# Altium schematic sheet lv_vbus.SchDoc(lv_vbus)
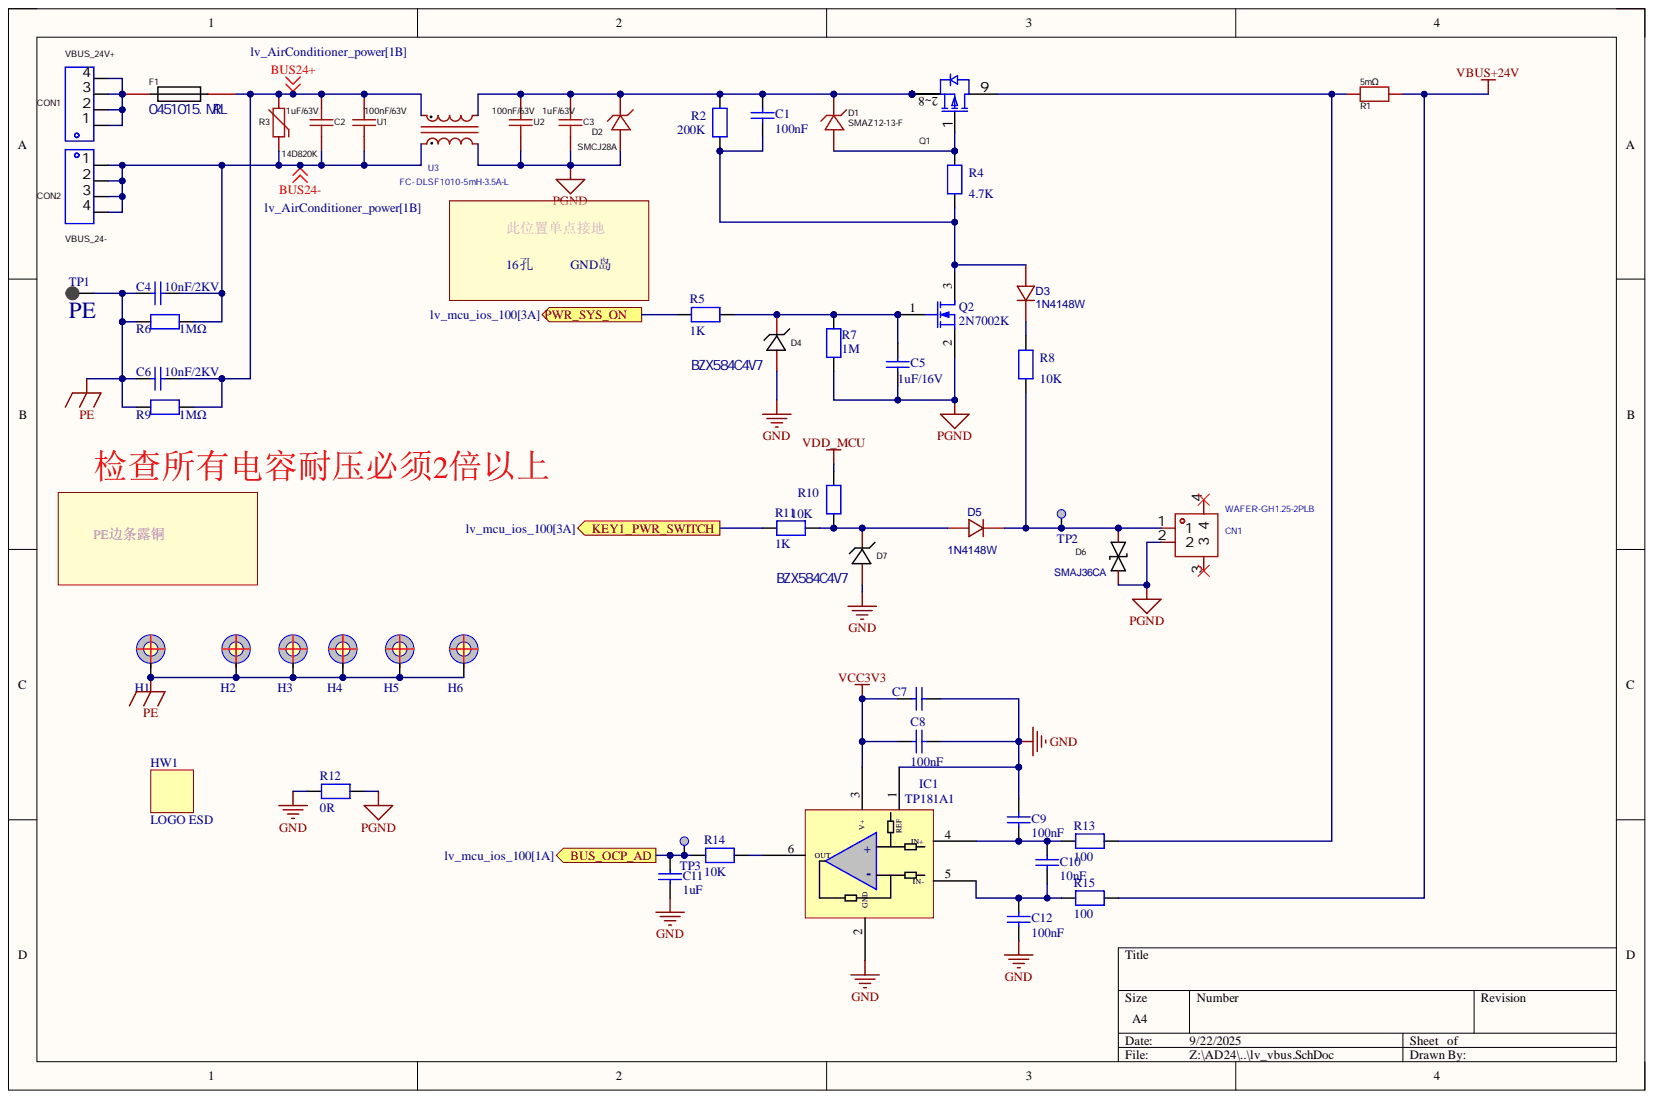
[diagram p0: lv_vbus.SchDoc]

## Parts
C1  100nF  [C0402]
C2  1uF/63V  [CAP-TH_L7.2-W5.0-P5.00-D1.0]
C3  1uF/63V  [CAP-TH_L7.2-W5.0-P5.00-D1.0]
C4  10nF/2KV  [c1812]
C5  1uF/16V  [C0603]
C6  10nF/2KV  [c1812]
C7  1uF/10V  [C0402]  (value not drawn)
C8  100nF  [C0402]
C9  100nF  [C0402]
C10  10nF  [C0402]
C11  1uF  [C0402]
C12  100nF  [C0402]
CN1  WAFER-GH1.25-2PLB  [CONN-TH_2P-P1.25_XUNPU_WAFER-GH1.25-2PLB]
CON1  VBUS_24V+  [CONN-TH_T44001]
CON2  VBUS_24-  [CONN-TH_T44001]
D1  SMAZ12-13-F  [SMA_L4.3-W2.6-LS5.2-RD]
D2  SMCJ28A  [SMC_L6.9-W5.9-LS7.9-RD]
D3  1N4148W  [SOD-123_L2.8-W1.8-LS3.7-RD]
D4  BZX584C4V7  [SOD-523F_L1.2-W0.8-LS1.7-RD]
D5  1N4148W  [SOD-123_L2.8-W1.8-LS3.7-RD]
D6  SMAJ36CA  [SMA_L4.4-W2.6-LS5.0-BI]
D7  BZX584C4V7  [SOD-523F_L1.2-W0.8-LS1.7-RD]
F1  0451015.MRL  [FUSE-SMD_L6.1-W2.6]
H1  POSITION HOLE C7D3R5  [PTH_350_700]  (value not drawn)  (no BOM)
H2  POSITION HOLE C7D3R5  [PTH_350_700]  (value not drawn)  (no BOM)
H3  POSITION HOLE C7D3R5  [PTH_350_700]  (value not drawn)  (no BOM)
H4  POSITION HOLE C7D3R5  [PTH_350_700]  (value not drawn)  (no BOM)
H5  POSITION HOLE C7D3R5  [PTH_350_700]  (value not drawn)  (no BOM)
H6  POSITION HOLE C7D3R5  [PTH_350_700]  (value not drawn)  (no BOM)
HW1  value LOGO ESD  [LOGO_MAIN_1]  (hidden comment: Logo_2)  (no BOM)
IC1  TP181A1  [SC-70-6_L2.2-W1.3-P0.65-LS2.1-BL]
Q1  SP60P03GHTO  [TOLL-8_L10.4-W9.9-P1.20-LS11.7-BL]  (value not drawn)
Q2  2N7002K  [SOT-23(SOT-23-3)]
R1  5mΩ  [RES-SMD_L7.1-W4.3_R2817]
R2  200K  [R0603]
R3  14D820K  [RES-TH_L16.5-W4.1-P7.50-D0.8-S4.10]
R4  4.7K  [0805]
R5  1K  [R0402]
R6  1MΩ  [1206]
R7  1M  [R0402]
R8  10K  [0805]
R9  1MΩ  [1206]
R10  10K  [R0402]
R11  1K  value 10K  [R0402]
R12  0R  [1206]
R13  100  [R0402]
R14  10K  [R0402]
R15  100  [R0402]
TP1  PE  [TP-1.5]
TP2  Test Point  [TP_SMD_1MM]  (value not drawn)  (no BOM)
TP3  Test Point  [TP_SMD_1MM]  (value not drawn)  (no BOM)
U1  100nF/63V  [CAP-TH_L7.2-W2.5-P5.00-D1.0]
U2  100nF/63V  [CAP-TH_L7.2-W2.5-P5.00-D1.0]
U3  FC- DLSF1010-5mH-3.5A-L  [XFMR-TH_FC-DLSF1010]

## Ports
BUS_OCP_AD, KEY1_PWR_SWITCH, PWR_SYS_ON

## Nets
BUS24+: C2.2 C6.2 F1.2 R3.2 R9.2 U1.2 U3.1
BUS24-: C2.1 C4.2 CON2.1 CON2.2 CON2.3 CON2.4 R3.1 R6.2 U1.1 U3.4
GND: C7.1 C8.2 C9.1 C11.2 C12.2 D4.2 D7.2 IC1.1(REF) IC1.2(GND) R12.1
PE: C4.1 C6.1 H1.1 H2.1 H3.1 H4.1 H5.1 H6.1 R6.1 R9.1 TP1.1
PGND: C3.1 C5.2 CN1.2 D2.2 D6.2 Q2.2 R7.1 R12.2 U2.1 U3.3
VBUS+24V: R1.2 R15.2
VCC3V3: C7.2 C8.1 IC1.3(V+)
VDD_MCU: R10.1
BUS_OCP_AD: C11.1 R14.2 TP3.1 ; port BUS_OCP_AD
KEY1_PWR_SWITCH: R11.1 ; port KEY1_PWR_SWITCH
PWR_SYS_ON: R5.1 ; port PWR_SYS_ON
NetC1_1: C1.1 D3.2 Q2.3 R2.2 R4.2
NetC1_2: C1.2 C3.2 D1.1 D2.1 Q1.2 Q1.3 Q1.4 Q1.5 Q1.6 Q1.7 Q1.8 R2.1 U2.2 U3.2
NetC5_1: C5.1 D4.1 Q2.1 R5.2 R7.2
NetC9_2: C9.2 C10.1 IC1.4(IN+) R13.1
NetC10_2: C10.2 C12.1 IC1.5(IN-) R15.1
NetCN1_1: CN1.1 D5.1 D6.1 R8.2 TP2.1
NetCON1_1: CON1.1 CON1.2 CON1.3 CON1.4 F1.1
NetD1_2: D1.2 Q1.1 R4.1
NetD3_1: D3.1 R8.1
NetD5_2: D5.2 D7.1 R10.2 R11.2
NetIC1_6: IC1.6(OUT) R14.1
NetQ1_9: Q1.9 R1.1 R13.2

Not connected: CN1 3 4

## Library data of the parts
C1 C8 C9 C12 = Capacitor: 50V, 10%, X7R; Internal_Part_Number: MCD-00003; Manufacturer: muRata; Manufacturer_Part_Number: GRM155R71H104KE14D; Component Kind: Standard; ComponentLink1Description: Datasheet; ComponentLink1URL: http://tunmcdsvn.tun.st.com/Component_Datasheet_Library/Trunk/Capacitors/Yageo_Capacitor_NP0_16V-to-50V; Library Name: HiPin_Library_AD.IntLib; Package: C0402; Value: 100nF
C2 C3 = MEB105J63V82CB0235: 精度±5%,额定电压63V,金属化聚酯; Manufacturer: KNSCHA(科尼盛); Component Kind: Standard; Library Name: HiPin_Library_AD.IntLib; Manufacturer_Part_Number: MEB105J63V82CB0235
C4 C6 = Capacitor_3: 2KV, X7R, 10%; Manufacturer: FH; Manufacturer_Part_Number: 1812B103K202NT; Component Kind: Standard; Library Name: HiPin_Library_AD.IntLib
C5 = MCD-00238: Manufacturer: YAGEO; Manufacturer_Part_Number: CC0603KRX5R7BB105; Database Table Name: MCD_PARTS$; Component Kind: Standard; ComponentLink1Description: Datasheet; ComponentLink1URL: http://tunmcdsvn.tun.st.com/Component_Datasheet_Library/Trunk/Capacitors/Yageo_Multilayer_capacitor_X5R_series_100pF_to_100uF; Library Name: MCD_PARTS_database.DbLib
C7 = Capacitor: 10V, X7R, ±10%; Manufacturer: muRata; Manufacturer_Part_Number: GRM155Z71A105KE01D; Component Kind: Standard; ComponentLink1Description: Datasheet; ComponentLink1URL: http://tunmcdsvn.tun.st.com/Component_Datasheet_Library/Trunk/Capacitors/Yageo_Capacitor_NP0_16V-to-50V; Library Name: HiPin_Library_AD.IntLib
C10 = Capacitor: 50V, 10%, X7R; Internal_Part_Number: MCD-00003; Manufacturer_Part_Number: GRM155R71H103KA88D; Component Kind: Standard; ComponentLink1Description: Datasheet; ComponentLink1URL: http://tunmcdsvn.tun.st.com/Component_Datasheet_Library/Trunk/Capacitors/Yageo_Capacitor_NP0_16V-to-50V; Library Name: HiPin_Library_AD.IntLib; Package: C0402; Value: 10nF
C11 = Capacitor: 10V, 10%, X7R; Internal_Part_Number: MCD-00003; Manufacturer_Part_Number: GRM155Z71A105KE01D; Component Kind: Standard; ComponentLink1Description: Datasheet; ComponentLink1URL: http://tunmcdsvn.tun.st.com/Component_Datasheet_Library/Trunk/Capacitors/Yageo_Capacitor_NP0_16V-to-50V; Library Name: HiPin_Library_AD.IntLib; Package: C0402; Value: 1uF
CN1 = WAFER-GH1.25-2PLB: 插座系列: GH,  立贴结构: 1x2P, 间距: 1.25mm; Manufacturer: XUNPU(讯普); Add into BOM: yes; Current Rating: 1A; Number of PINs Per Row: 2; Plastic Material: LCP; Supplementary Features: 辅助焊脚; Voltage Rating: 125V; X-Length of Bottom Edge on Board (Spacing Line): 5.75mm; Y-Width of Bottom Edge on Board: 4.95mm; Z-Height of the Board: 4.2mm; Color: 米色; Component Kind: Standard; Contact Material: 黄铜; Contact Plating: -; Convert to PCB: yes; Datasheet: https://atta.szlcsc.com/upload/public/pdf/source/20220527/44F824AAC8C2B617205E15955FF9C23D.pdf; Device: WAFER-GH1.25-2PLB; Flame Retardant Rating: -; JLCPCB Part Class: Extended Part; LCSC Part Name: 1x2P 间距:1.25mm 立贴 系列:GH; Library Name: HiPin_Library_AD.IntLib; Manufacturer Part: WAFER-GH1.25-2PLB; Mounting Type: 立贴; Number of Pins: 2P; Number of Rows: 1; Operating Temperature: -25℃~+85℃; PCB3D: CONN-TH_2P-P1.25_XUNPU_WAFER-GH1.25-2PLB; Pins Structure: 1x2P; Pitch: 1.25mm; Reference Series: GH; Row Spacing: -; Supplier: LCSC; Supplier Footprint: SMD,P=1.25mm; Supplier Part: C3029368; Symbol: WAFER-GH1.25-2PLB
CON1 CON2 = T44001: Manufacturer: XFCN(兴飞); Add into BOM: yes; Component Kind: Standard; Convert to PCB: yes; Datasheet: https://atta.szlcsc.com/upload/public/pdf/source/20200107/C481448_4214B4EB74A46B70BD82BA8A456D4EDD.pdf; Device: T44001; JLCPCB Part Class: Extended Part; LCSC Part Name: 四脚 M4 二件套; Library Name: HiPin_Library_AD.IntLib; Manufacturer Part: T44001; Supplier: LCSC; Supplier Footprint: 插件; Supplier Part: C481447; Symbol: T44001
D1 = SMAZ12-13-F: 稳压二极管; Manufacturer: DIODES(美台); Add into BOM: yes; Diode Configuration: 独立式; Power Dissipation: 1W; Reverse Leakage Current (Ir): 1uA@9.1V; Tolerance: ±5%; Zener Impedance (Zzt): 7Ω; Zener Voltage (Nom): 12V; Zener Voltage (Range): 11.4V~12.6V; Component Kind: Standard; Convert to PCB: yes; Datasheet: https://atta.szlcsc.com/upload/public/pdf/source/20191028/C442456_7FB4DBCAA017352A40A80784B71299E4.pdf; Device: SMAZ12-13-F; JLCPCB Part Class: Extended Part; LCSC Part Name: SMAZ12-13-F; Library Name: HiPin_Library_AD.IntLib; Manufacturer Part: SMAZ12-13-F; PCB3D: SMA_L4.3-W2.6-LS5.2-RD; Supplier: LCSC; Supplier Footprint: SMA(DO-214AC); Supplier Part: C110523; Symbol: SMAZ12-13-F
D2 = SMCJ28A_C693618: Manufacturer: FTR(乔光电子); Add into BOM: yes; Breakdown Voltage: 31.1V; Maximum Clamping Voltage: 45.4V; Peak Pulse Current (Ipp)@10/1000us: 33.1A; polarity: 单向; Reverse Stand-Off Voltage (Vrwm): 28V; Type: TVS; Component Kind: Standard; Convert to PCB: yes; Datasheet: https://atta.szlcsc.com/upload/public/pdf/source/20200702/C693547_038122F463C15F3D55BC5385490E6510.pdf; Device: SMCJ28A_C693618; JLCPCB Part Class: Extended Part; LCSC Part Name: SMCJ28A; Library Name: HiPin_Library_AD.IntLib; Manufacturer Part: SMCJ28A; Supplier: LCSC; Supplier Footprint: SMC(DO-214AB); Supplier Part: C693618; Symbol: SMCJ28A_C693618
D3 D5 = 1N4148W-C84367: BOM_Manufacturer: MDD; BOM_JLCPCB Part Class: Extended Part; BOM_Manufacturer Part: 1N4148W; BOM_Supplier: LCSC; BOM_Supplier Part: C84367; Component Kind: Standard; Library Name: HiPin_Library_AD.IntLib; spicePre: D; spiceSymbolName: 1N4148W-C84367
D4 D7 = LM5Z3V6T1G: 4.8~5.4V, 200mW; Power Dissipation: 500mW; Component Kind: Standard; Library Name: HiPin_Library_AD.IntLib; Manufacturer Part: BZX584C4V7; Supplier Footprint: SOD-123; Tolerance: -
  D7: PCB3D: SOD-123_L2.7-W1.6-H1.4-LS3.7
D6 = SMAJ6.8CA_C406209: 极性: 双向  反向截止电压(Vrwm): 36V  钳位电压: 58.1V  峰值脉冲电流(Ipp): 6.9A; Manufacturer: BORN(�����뵼��); Add into BOM: yes; Reverse Stand-Off Voltage (Vrwm): 6.8V; Type: TVS; Component Kind: Standard; Convert to PCB: yes; Datasheet: https://atta.szlcsc.com/upload/public/pdf/source/20190726/C406209_A574BC8626299E1E05AC1B67D2991239.pdf; JLCPCB Part Class: Extended Part; LCSC Part Name: ˫��TVS 6.8V��ֹ; Library Name: HiPin_Library_AD.IntLib; Manufacturer Part: SMAJ36CA; Supplier: LCSC; Supplier Footprint: SMA(DO-214AC); Supplier Part: C406209
F1 = 0451015.MRL: Manufacturer: Littelfuse(美国力特); Add into BOM: yes; Breaking Capacity: 50A; Current Rating: 15A; Type: 贴片式保险丝; Voltage Rating  (AC): 65V; Component Kind: Standard; Convert to PCB: yes; Datasheet: https://atta.szlcsc.com/upload/public/pdf/source/20151106/1457707549464.pdf; Device: 0451015.MRL; JLCPCB Part Class: Extended Part; LCSC Part Name: 65V贴片式保险丝; Library Name: HiPin_Library_AD.IntLib; Manufacturer Part: 0451015.MRL; Name: 0451015.MRL; Operating Temperature: -55℃~+125℃; PCB3D: FUSE-SMD_L6.1-W2.6-H2.6; Supplier: LCSC; Supplier Footprint: SMD; Supplier Part: C44480; Symbol: 0451015.MRL; Voltage Rating (DC): 65V
H1-H6 = MCD-00328: 螺丝焊盘; Part_Type: Mechanical; Initial_Board_Reference: MB1311; Item Type: Item; Path: ws/MCD_ApplicationBoards/Lists/MCDPARTSdatabase; ComponentLink1Description: Datasheet; Internal_Part_Number: MCD-00328; Library Name: HiPin_Library_AD.IntLib; Provided_By: n/a; Status: Released
HW1 = Logo_2: Hand ESD logo footprint for PCB; Internal_Part_Number: MCD-00627; Manufacturer: NONE; Part_Type: Mechanical; ComponentLink1Description: Datasheet; ComponentLink1URL: http://na; ECCN_EU: n/a; ECCN_US: n/a; Library Name: HiPin_Library_AD.IntLib; Manufacturer_Part_Number: NONE; Provided_By: n/a; Status: Obsolete
IC1 = TP181: Component Kind: Standard; Library Name: HiPin_Library_AD.IntLib
Q1 = SP60P03GHTO: PMOS; Manufacturer: HUAYI(����΢); Add into BOM: yes; Continuous Drain Current (Id): 380A; Device: HYG015N10NS1TA; Drain Source On Resistance (RDS(on)@Vgs,Id): 1.2m��@10V,100A; Drain Source Voltage (Vdss): 100V; Gate Threshold Voltage (Vgs(th)@Id): 3V@250uA; Input Capacitance (Ciss@Vds): 12.3nF@25V; Power Dissipation (Pd): 428.5W; Reverse Transfer Capacitance (Crss@Vds): 250pF@25V; Total Gate Charge (Qg@Vgs): 205nC@10V; Type: N����; Component Kind: Standard; Convert to PCB: yes; Datasheet: https://atta.szlcsc.com/upload/public/pdf/source/20220303/83427DBE0CDF10F23F3FDD49B9C9CFAE.pdf; JLCPCB Part Class: Extended Part; LCSC Part Name: N���� 100V 380A; Library Name: HiPin_Library_AD.IntLib; Manufacturer Part: HYG015N10NS1TA; Operating Temperature: -55��~+175��@(Tj); Supplier: LCSC; Supplier Footprint: TOLL-8; Supplier Part: C2979263; Symbol: HYG015N10NS1TA
Q2 = MOSFET-N: N沟道,60V,0.115A,7Ω@10V; Manufactu: CJ江苏长电; ManufacturerNumber: 2N7002; Component Kind: Standard; Library Name: HiPin_Library_AD.IntLib; Package: SOT-23; SuppliersPartNumber: C8545
R1 = HoXLR2817-5W-5mR-1%: 5W， 5mΩ; Manufacturer: Milliohm(毫欧); Add into BOM: yes; Power(Watts): 5W; Temperature Coefficient: ±50ppm/℃; Tolerance: ±1%; Component Kind: Standard; Convert to PCB: yes; Current Rating: -; Datasheet: https://atta.szlcsc.com/upload/public/pdf/source/20220719/E9E1BF5D60DBAB1363D073E6120BE8EB.pdf; Device: HoXLR2817-5W-5mR-1%; JLCPCB Part Class: Extended Part; LCSC Part Name: 5mΩ ±1% 5W; Library Name: HiPin_Library_AD.IntLib; Manufacturer Part: HoXLR2817-5W-5mR-1%; Mounting Type: 贴片; PCB3D: RES-SMD_L7.1-W4.3_R2817; Supplier: LCSC; Supplier Footprint: 2817; Supplier Part: C4944048; Symbol: HOXLR2817-5W-5MR-1%; Type: 采样电阻; Value: 5mΩ; Voltage Rating: -
R2 R4 R5 R7 R12 R13 R15 = RES: 1%; Value: 10K; Component Kind: Standard; Library Name: HiPin_Library_AD.IntLib
R3 = 14D820K_C233153: Manufacturer: RUILON(瑞隆源); Add into BOM: yes; Allowable Voltage (AC): 50V; Allowable Voltage (DC): 65V; Clamping Voltage: 135V; Maximum Energy: 14.8J; Peak Current: 4.5kA; Typical Capatitance: 3.9nF@1kHz; Varistor Voltage: 74V~90V; Component Kind: Standard; Convert to PCB: yes; Datasheet: https://atta.szlcsc.com/upload/public/pdf/source/20180619/C233163_66B3D320ED6E5BF2A723EB1D6754D703.pdf; Device: 14D820K_C233153; JLCPCB Part Class: Extended Part; LCSC Part Name: 钳位电压135V 14.8焦耳 插件压敏电阻; Library Name: HiPin_Library_AD.IntLib; Manufacturer Part: 14D820K; Supplier: LCSC; Supplier Footprint: 插件; Supplier Part: C233153; Symbol: 14D820K_C233153
R6 R9 = RES: 1%; Manufacturer: YAGEO; Manufacturer_Part_Number: RC1206FR-071ML; Component Kind: Standard; Library Name: HiPin_Library_AD.IntLib
R8 R10 R11 R14 = RES: 1%; Component Kind: Standard; Library Name: HiPin_Library_AD.IntLib
  R8 R10 R14: Value: 10K
TP1 = TEST-POINT: 测试点; Component Kind: Standard; Library Name: HiPin_Library_AD.IntLib
TP2 TP3 = MCD-00074: Test Point 1mm; Part_Type: Mechanical; Database Table Name: MCD_PARTS$; ComponentLink1Description: Datasheet; ComponentLink1URL: http://na; ECCN_EU: n/a; ECCN_US: n/a; Internal_Part_Number: MCD-00074; Library Name: MCD_PARTS_database.DbLib; Manufacturer: n/a; Manufacturer_Part_Number: n/a; Provided_By: n/a; Status: Released
U1 U2 = MEB104J63V82CB0236: Manufacturer: KNSCHA(科尼盛); Add into BOM: yes; Dielectric Material: 金属化聚酯; Tolerance: ±5%; Value: 100nF; Voltage Rated: 63V; Component Kind: Standard; Convert to PCB: yes; Datasheet: https://atta.szlcsc.com/upload/public/pdf/source/20220613/95E40529FEB7A3E68EC83AD5A7304622.pdf; Device: MEB104J63V82CB0236; JLCPCB Part Class: Extended Part; LCSC Part Name: 100nF ±5% 63V; Library Name: HiPin_Library_AD.IntLib; Manufacturer_Part_Number: MEB104J63V82CB0236; Operating Temperature: -40℃~+110℃; Supplier: LCSC; Supplier Footprint: 插件,P=5mm; Supplier Part: C3034535; Symbol: MEB104J63V82CB0236
U3 = FC- DLSF1010-5mH-3.5A-L: Manufacturer: FC(方成); Add into BOM: yes; Current Rating: 3.5A; DC Resistance(DCR): 85mΩ; Inductance @ Frequency: 5mH; Number of Lines: 2; Voltage Rating (AC): 265V; Voltage Withstand: 1.5kV@AC,1mins; Component Kind: Standard; Convert to PCB: yes; Datasheet: https://atta.szlcsc.com/upload/public/pdf/source/20230918/E059DB83B2619EC93AA5E962655101CA.pdf; Device: FC- DLSF1010-5mH-3.5A-L; JLCPCB Part Class: Extended Part; LCSC Part Name: FC- DLSF1010-5mH-3.5A-L; Library Name: HiPin_Library_AD.IntLib; Manufacturer Part: FC- DLSF1010-5mH-3.5A-L; Operating Temperature: -40℃~+125℃; PCB3D: XFMR-TH_FC-DLSF1010; Supplier: LCSC; Supplier Footprint: DIP; Supplier Part: C7295674; Symbol: FC- DLSF1010-5MH-3.5A-L
